# Altium schematic sheet POWER.SchDoc
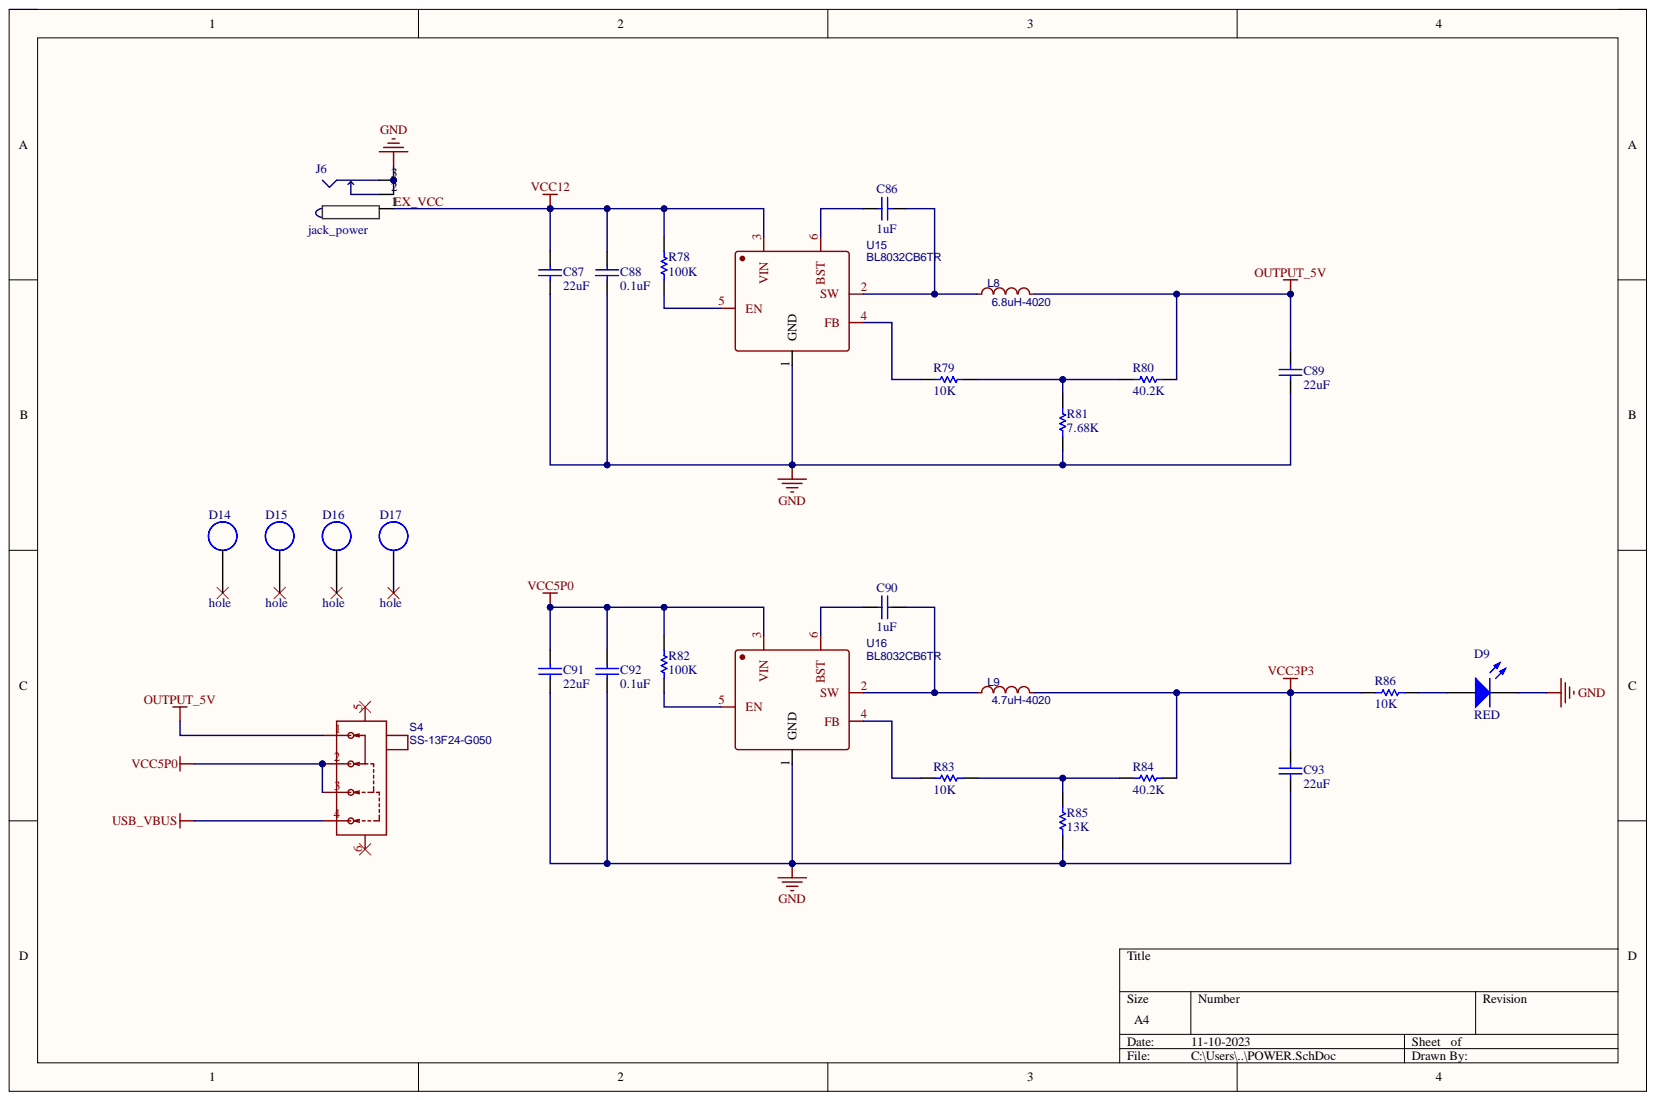
[diagram p0: POWER.SchDoc]

## Parts
C86  1uF  [C0603]
C87  22uF  [C1206]
C88  0.1uF  [C0402]
C89  22uF  [C1206]
C90  1uF  [C0603]
C91  22uF  [C1206]
C92  0.1uF  [C0402]
C93  22uF  [C1206]
D9  RED  [0603_LED]
D14  hole  [HOLE5R715MM3R175MM-8STAR]
D15  hole  [HOLE5R715MM3R175MM-8STAR]
D16  hole  [HOLE5R715MM3R175MM-8STAR]
D17  hole  [HOLE5R715MM3R175MM-8STAR]
J6  jack_power  [DS-0205-2_0]
L8  6.8uH-4020  [ind-smd_l4_0-w4_0]
L9  4.7uH-4020  [ind-smd_l4_0-w4_0]
R78  100K  [C0402]
R79  10K  [R0402]
R80  40.2K  [C0402]
R81  7.68K  [0603R]
R82  100K  [C0402]
R83  10K  [R0402]
R84  40.2K  [C0402]
R85  13K  [R0402]
R86  10K  [R0402]
S4  SS-13F24-G050  [sw-th_ss-13f24-g050]
U15  BL8032CB6TR  [SOT-23-6]
U16  BL8032CB6TR  [SOT-23-6]

## Nets
GND: C87.2 C88.2 C89.2 C91.2 C92.2 C93.2 D9.2 J6.2 J6.3 R81.1 R85.1 U15.1(GND) U16.1(GND)
OUTPUT_5V: C89.1 L8.2 R80.2 S4.1
USB_VBUS: S4.4
VCC3P3: C93.1 L9.2 R84.2 R86.1
VCC5P0: C91.1 C92.1 R82.1 S4.2 S4.3 U16.3(VIN)
EX_VCC: C87.1 C88.1 J6.1 R78.1 U15.3(VIN)
NetC86_1: C86.1 L8.1 U15.2(SW)
NetC86_2: C86.2 U15.6(BST)
NetC90_1: C90.1 L9.1 U16.2(SW)
NetC90_2: C90.2 U16.6(BST)
NetD9_1: D9.1 R86.2
NetR78_2: R78.2 U15.5(EN)
NetR79_1: R79.1 U15.4(FB)
NetR79_2: R79.2 R80.1 R81.2
NetR82_2: R82.2 U16.5(EN)
NetR83_1: R83.1 U16.4(FB)
NetR83_2: R83.2 R84.1 R85.2

Not connected: D14 1; D15 1; D16 1; D17 1; S4 5 6

## Library data of the parts
C86-C92 = Cap: Capacitor; Component Kind: Standard; LatestRevisionDate: 17-Jul-2002; LatestRevisionNote: Re-released for DXP Platform.; Library Name: Miscellaneous Devices.IntLib; PackageDescription: Radial Cap, Thru-Hole; 2 Leads; 0.3 in Pin Spacing; PackageReference: RAD-0.3; Published: 8-Jun-2000; Signal Integrity: Cap; Simulation: CAP; Publisher: Altium Limited
  C86 C90: Value: 1uF
  C87 C89 C91: Value: 22uF
  C88 C92: Value: 0.1uF
C93 = Cap: Capacitor  25V; Component Kind: Standard; LatestRevisionDate: 17-Jul-2002; LatestRevisionNote: Re-released for DXP Platform.; Library Name: Miscellaneous Devices.IntLib; PackageDescription: Radial Cap, Thru-Hole; 2 Leads; 0.3 in Pin Spacing; PackageReference: RAD-0.3; Published: 8-Jun-2000; Signal Integrity: Cap; Simulation: CAP; Value: 22uF; Publisher: Altium Limited
D9 = LED2: Typical RED, GREEN, YELLOW, AMBER GaAs LED; Component Kind: Standard; LatestRevisionDate: 17-Jul-2002; LatestRevisionNote: Re-released for DXP Platform.; Library Name: Miscellaneous Devices.IntLib; PackageDescription: SM LED; 2 Flat Leads; PackageReference: 3.2X1.6X1.1; PackageVersion: Feb-2002; Published: 8-Jun-2000; Value: RED; Publisher: Altium Limited
J6 = jack_pwr: Component Kind: Standard; Description: jack_pwr; Library Name: zynq.SCHLIB; Value: jack_power
L8 L9 = 4.7uH: BOM_Manufacturer: Sunlord; BOM_Manufacturer Part: SWPA4030S4R7MT; nameAlias: Inductance; BOM_JLCPCB Part Class: Extended Part; BOM_Supplier: LCSC; BOM_Supplier Part: C57269; Component Kind: Standard; Library Name: zynq.SCHLIB; spicePre: L; spiceSymbolName: SWPA4030S4R7MT
R78-R86 = Res1: Resistor; Component Kind: Standard; LatestRevisionDate: 17-Jul-2002; LatestRevisionNote: Re-released for DXP Platform.; Library Name: Miscellaneous Devices.IntLib; PackageDescription: Axial Device, Thru-Hole; 2 Leads; 0.3 in Pin Spacing; PackageReference: AXIAL-0.3; Published: 8-Jun-2000; Signal Integrity: Res; Simulation: RESISTOR; Publisher: Altium Limited
  R78 R82: Value: 100K
  R79 R83 R86: Value: 10K
  R80 R84: Value: 40.2K
  R81: Value: 7.68K
  R85: Value: 13K
S4 = SS-13F24-G050: 单刀三置; BOM_Manufacturer: G-Switch(品赞); Contributor: LCSC; BOM_Manufacturer Part: SS-13F24-G050; BOM_Supplier: LCSC; BOM_Supplier Part: C2848935; Component Kind: Standard; Library Name: zynq.SCHLIB; spicePre: S; spiceSymbolName: SS-13F24-G050
U15 U16 = BL8032CB6TR: BOM_Manufacturer: BL; Contributor: LCSC; BOM_JLCPCB Part Class: Extended Part; BOM_Manufacturer Part: BL8032CB6TR; BOM_Supplier: LCSC; BOM_Supplier Part: C93180; Component Kind: Standard; Library Name: zynq.SCHLIB; spicePre: U; spiceSymbolName: BL8032CB6TR
D14-D17 = hole: Component Kind: Standard; Description: hole; Library Name: Schlib1.SchLib
